# Altium schematic sheet OI-projet-P1.SchDoc(OI-projet-P1)
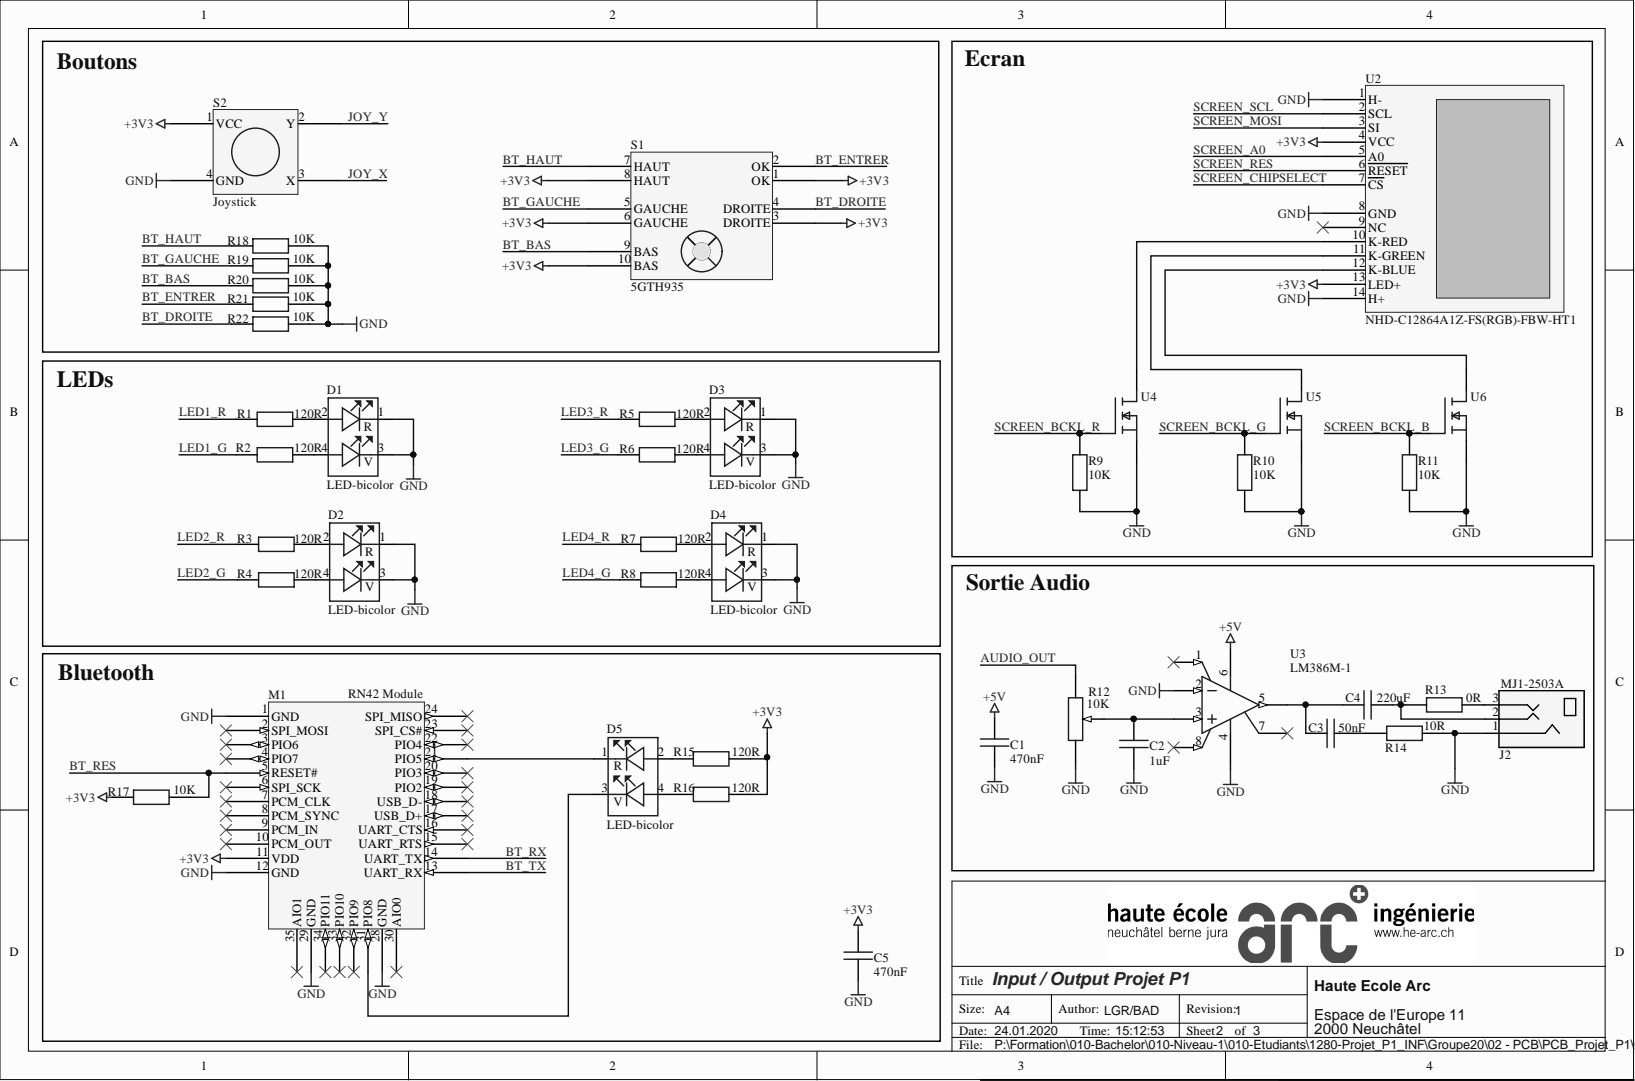
[diagram p0: OI-projet-P1.SchDoc]

## Parts
C1  470nF  [080501]
C2  1uF  [080501]
C3  50nF  [080501]
C4  220uF  [120601]
C5  470nF  [080501]
D1  LED-bicolor  [D00101-2]
D2  LED-bicolor  [D00101-2]
D3  LED-bicolor  [D00101-2]
D4  LED-bicolor  [D00101-2]
D5  LED-bicolor  [D00101-2]
J2  MJ1-2503A  [CUI_MJ1-2503A]
M1  RN42 Module  [RN42-LAND-3D]  (no BOM)
R1  120R  [080501]
R2  120R  [080501]
R3  120R  [080501]
R4  120R  [080501]
R5  120R  [080501]
R6  120R  [080501]
R7  120R  [080501]
R8  120R  [080501]
R9  10K  [080501]
R10  10K  [080501]
R11  10K  [080501]
R12  10K  [CT-6EP]
R13  0R  [080501]
R14  10R  [080501]
R15  120R  [080501]
R16  120R  [080501]
R17  10K  [080501]
R18  10K  [080501]
R19  10K  [080501]
R20  10K  [080501]
R21  10K  [080501]
R22  10K  [080501]
S1  5GTH935  [CONTROLMEC]
S2  Joystick  [Adafruit_3246]
U2  NHD-C12864A1Z-FS(RGB)-FBW-HT1  [NHD-C12864A1Z-FSW-FB(RGB)-HTT - integration 2]
U3  LM386M-1  [TI-D8_N]
U4  POWER-MOSFET  [SOT2301]  (value not drawn)
U5  POWER-MOSFET  [SOT2301]  (value not drawn)
U6  POWER-MOSFET  [SOT2301]  (value not drawn)

## Nets
+3V3: C5.1 M1.11(VDD) R15.2 R16.2 R17.1 S1.1(OK) S1.3(DROITE) S1.6(GAUCHE) S1.8(HAUT) S1.10(BAS) S2.1(VCC) U2.4(VCC) U2.13(LED+)
+5V: C1.1 U3.6
GND: C1.2 C2.2 C5.2 D1.1 D1.3 D2.1 D2.3 D3.1 D3.3 D4.1 D4.3 J2.1 M1.1(GND) M1.12(GND) M1.28(GND) M1.29(GND) R9.1 R10.1 R11.1 R12.1 R14.1 R18.2 R19.2 R20.2 R21.2 R22.2 S2.4(GND) U2.1(H-) U2.8(GND) U2.14(H+) U3.2 U3.4 U4.2 U5.2 U6.2
AUDIO_OUT: R12.3
BT_BAS: R20.1 S1.9(BAS)
BT_DROITE: R22.1 S1.4(DROITE)
BT_ENTRER: R21.1 S1.2(OK)
BT_GAUCHE: R19.1 S1.5(GAUCHE)
BT_HAUT: R18.1 S1.7(HAUT)
BT_RES: M1.5(RESET#) R17.2
BT_RX: M1.14(UART_TX)
BT_TX: M1.13(UART_RX)
JOY_X: S2.3(X)
JOY_Y: S2.2(Y)
LED1_G: R2.2
LED1_R: R1.2
LED2_G: R4.2
LED2_R: R3.1
LED3_G: R6.2
LED3_R: R5.2
LED4_G: R8.2
LED4_R: R7.2
SCREEN_A0: U2.5(A0)
SCREEN_BCKL_B: R11.2 U6.1
SCREEN_BCKL_G: R10.2 U5.1
SCREEN_BCKL_R: R9.2 U4.1
SCREEN_CHIPSELECT: U2.7(CS)
SCREEN_MOSI: U2.3(SI)
SCREEN_RES: U2.6(RESET)
SCREEN_SCL: U2.2(SCL)
NetC2_1: C2.1 R12.2 U3.3
NetC3_1: C3.1 C4.1 U3.5
NetC3_2: C3.2 R14.2
NetC4_2: C4.2 J2.2 R13.1
NetD1_2: D1.2 R1.1
NetD1_4: D1.4 R2.1
NetD2_2: D2.2 R3.2
NetD2_4: D2.4 R4.1
NetD3_2: D3.2 R5.1
NetD3_4: D3.4 R6.1
NetD4_2: D4.2 R7.1
NetD4_4: D4.4 R8.1
NetD5_1: D5.1 M1.21(PIO5)
NetD5_2: D5.2 R15.1
NetD5_3: D5.3 M1.31(PIO8)
NetD5_4: D5.4 R16.1
NetJ2_3: J2.3 R13.2
NetU2_10: U2.10(K-RED) U4.3
NetU2_11: U2.11(K-GREEN) U5.3
NetU2_12: U2.12(K-BLUE) U6.3

Not connected: M1 2(SPI_MOSI) 3(PIO6) 4(PIO7) 6(SPI_SCK) 7(PCM_CLK) 8(PCM_SYNC) 9(PCM_IN) 10(PCM_OUT) 15(UART_RTS) 16(UART_CTS) 17(USB_D+) 18(USB_D-) 19(PIO2) 20(PIO3) 22(PIO4) 23(SPI_CS#) 24(SPI_MISO) 30(AIO0) 32(PIO9) 33(PIO10) 34(PIO11) 35(AIO1); U2 9(NC); U3 1 7 8

## Library data of the parts
C1 C5 = Cap-470nF: Condensateur de 470nF 0805; Ref. Fournisseur: 399-8100-1-ND; Ref. Fabricant: C0805C474K5RACTU; Prix 1 unit (CHF): 0.35; Fournisseur: Digikey; Fabricant: KEMET; Auteur: BAD; Library Name: Schlib-P1-G20.SchLib; ComponentLink1Description: datasheet; Component Kind: Standard; ComponentLink1URL: P:\Formation\010-Bachelor\010-Niveau-1\010-Etudiants\1280-Projet_P1_INF\Groupe20\01 - Documentation\01 - Composants electronique\11 - Composants passif\02 - Condensateur\KEM_C1002_X7R_SMD.pdf
C2 = Cap-1uF: Condensateur de 1uF 0805; Ref. Fournisseur: 399-7409-1-ND; Ref. Fabricant: C0805C105K5RACTU; Prix 1 unit (CHF): 0.4; Fournisseur: Digikey; Fabricant: KEMET; Auteur: BAD; Library Name: Schlib-P1-G20.SchLib; ComponentLink1Description: datasheet; Component Kind: Standard; ComponentLink1URL: P:\Formation\010-Bachelor\010-Niveau-1\010-Etudiants\1280-Projet_P1_INF\Groupe20\01 - Documentation\01 - Composants electronique\11 - Composants passif\02 - Condensateur\KEM_C1002_X7R_SMD.pdf
C3 = Cap-50nF: Condensateur de 50nF 0805; Ref. Fournisseur: 311-1431-1-ND; Ref. Fabricant: CC0805KRX7R9BB473; Prix 1 unit (CHF): 0.13; Fournisseur: Digikey; Fabricant: Yageo; Auteur: BAD; Library Name: Schlib-P1-G20.SchLib; ComponentLink1Description: datasheet; Component Kind: Standard; ComponentLink1URL: P:\Formation\010-Bachelor\010-Niveau-1\010-Etudiants\1280-Projet_P1_INF\Groupe20\01 - Documentation\01 - Composants electronique\11 - Composants passif\02 - Condensateur\C0GNP0-Dielectric.pdf
C4 = Cap-220uF: Condensateur de 220uF 1206; Ref. Fournisseur: 490-13970-1-ND; Ref. Fabricant: GRM31CR60J227ME11L; Prix 1 unit (CHF): 1.50; Fournisseur: Digikey; Fabricant: Murata Electronics; Auteur: BAD; Library Name: Schlib-P1-G20.SchLib; ComponentLink1Description: datasheet; Component Kind: Standard; ComponentLink1URL: P:\Formation\010-Bachelor\010-Niveau-1\010-Etudiants\1280-Projet_P1_INF\Groupe20\01 - Documentation\01 - Composants electronique\11 - Composants passif\02 - Condensateur\GRM31CR60J227ME11-01.pdf
D1-D5 = XZMDKVG45S: Led bicolore; Ref. Fournisseur: 1497-1181-1-ND; Prix 1 unit (CHF): 0.54; Fournisseur: Digikey; Fabricant: SunLED; Auteur: BAD; Ref. Fabricant: XZMDKVG45S; Library Name: Schlib-P1-G20.SchLib; ComponentLink1Description: datasheet; Component Kind: Standard; ComponentLink1URL: P:\Formation\010-Bachelor\010-Niveau-1\010-Etudiants\1280-Projet_P1_INF\Groupe20\01 - Documentation\01 - Composants electronique\08 - LED\XZMDKVG45S.pdf
J2 = MJ1-2503A: Ref. Fournisseur: CP1-2503AM-ND; Prix 1 unit (CHF): 0.67; Fournisseur: Digikey; Fabricant: CUI Devices; Auteur: BAD; Ref. Fabricant: MJ1-2503A; Library Name: Schlib-P1-G20.SchLib; ComponentLink1Description: datasheet; Component Kind: Standard; ComponentLink1URL: P:\Formation\010-Bachelor\010-Niveau-1\010-Etudiants\1280-Projet_P1_INF\Groupe20\01 - Documentation\01 - Composants electronique\10 - Connecteurs\jack\jack-mj1-2503a.pdf
M1 = RN42MOD: Ref. Fournisseur: 765-RN-42; Ref. Fabricant: RN42-I/RM; Prix 1 unit (CHF): 15.59; Fournisseur: Mouser; Fabricant: Microchip; Auteur: BAD; Library Name: Schlib-P1-G20.SchLib; Description: RN42 Module; ComponentLink1Description: datasheet; ComponentLink1URL: P:\Formation\010-Bachelor\010-Niveau-1\010-Etudiants\1280-Projet_P1_INF\Groupe20\01 - Documentation\01 - Composants electronique\09 - Bluetooth\RN42-I.pdf
R1-R8 R15 R16 = Res-120R-0805: Résistance 120 ohm 0805 0.125W; Ref. Fournisseur: 311-120CRCT-ND; Ref. Fabricant: RC0805FR-07120RL; Prix 1 unit (CHF): 0.10; Fournisseur: Digikey; Fabricant: Yageo; Auteur: BAD; Library Name: Schlib-P1-G20.SchLib; ComponentLink1Description: datasheet; Component Kind: Standard; ComponentLink1URL: P:\Formation\010-Bachelor\010-Niveau-1\010-Etudiants\1280-Projet_P1_INF\Groupe20\01 - Documentation\01 - Composants electronique\11 - Composants passif\01 - Résistances\res-100K-0805.pdf
R9-R11 R17-R22 = Res-10K-0805: Résistance 10 K ohm 0805 0.125W; Ref. Fournisseur: 311-10.0KCRCT-ND; Ref. Fabricant: RC0805FR-0710KL; Prix 1 unit (CHF): 0.10; Fournisseur: Digikey; Fabricant: Yageo; Auteur: BAD; Library Name: Schlib-P1-G20.SchLib; ComponentLink1Description: datasheet; Component Kind: Standard; ComponentLink1URL: P:\Formation\010-Bachelor\010-Niveau-1\010-Etudiants\1280-Projet_P1_INF\Groupe20\01 - Documentation\01 - Composants electronique\11 - Composants passif\01 - Résistances\res-100K-0805.pdf
R12 = CT6EP103: Potentiomètre; Ref. Fournisseur: CT6EP103-ND; Prix 1 unit (CHF): 0.78; Fournisseur: Digikey; Fabricant: Nidec Copal Electronics; Auteur: BAD; Ref. Fabricant: CT6EP103; Library Name: Schlib-P1-G20.SchLib; ComponentLink1Description: datasheet; Component Kind: Standard; ComponentLink1URL: P:\Formation\010-Bachelor\010-Niveau-1\010-Etudiants\1280-Projet_P1_INF\Groupe20\01 - Documentation\01 - Composants electronique\11 - Composants passif\04 - Potentiomètre\10 K\ct-6.pdf
R13 = Res_0R-0805: Résistance 0 R 0805 0.25W; Ref. Fournisseur: RMCF0805ZT0R00CT-ND; Ref. Fabricant: RMCF0805ZT0R00; Prix 1 unit (CHF): 0.10; Fournisseur: Digikey; Fabricant: Stackpole Electronics Inc; Auteur: BAD; Library Name: Schlib-P1-G20.SchLib; ComponentLink1Description: datasheet; Component Kind: Standard; ComponentLink1URL: P:\Formation\010-Bachelor\010-Niveau-1\010-Etudiants\1280-Projet_P1_INF\Groupe20\01 - Documentation\01 - Composants electronique\11 - Composants passif\01 - Résistances\sei-packaging.pdf
R14 = Res-10R-0805: Résistance 10 R 0805 0.25W; Ref. Fournisseur: RNCP0805FTD10R0CT-ND; Ref. Fabricant: RNCP0805FTD10R0; Prix 1 unit (CHF): 0.10; Fournisseur: Digikey; Fabricant: Stackpole Electronics Inc; Auteur: BAD; Library Name: Schlib-P1-G20.SchLib; ComponentLink1Description: datasheet; Component Kind: Standard; ComponentLink1URL: P:\Formation\010-Bachelor\010-Niveau-1\010-Etudiants\1280-Projet_P1_INF\Groupe20\01 - Documentation\01 - Composants electronique\11 - Composants passif\01 - Résistances\res-150-0805.pdf
S1 = CONTROLMEC: digikey :  5 x 679-2612-ND   4 x 679-2303-ND   1 x 679-2302-ND; Ref. Fournisseur: 5 x 679-2612-ND / 4 x 679-2303-ND / 1 x 679-2302-ND; Ref. Fabricant: 5 x 5GTH935 / 4 x 1ZB09D13606 / 1 x 1ZC0911806; Prix 1 unit (CHF): 24.61; Fabricant: APEM; Auteur: BAD; Library Name: Schlib-P1-G20.SchLib; Fournisseur: Digikey; ComponentLink1Description: datasheet; Component Kind: Standard; ComponentLink1URL: P:\Formation\010-Bachelor\010-Niveau-1\010-Etudiants\1280-Projet_P1_INF\Groupe20\01 - Documentation\01 - Composants electronique\07 - Boutons et joystick\MEC-directionnel\MEC-switches-serie-MULTIMEC3F.pdf
S2 = Analog mini Thumbstick: Ref. Fournisseur: 2765 / 3246; Prix 1 unit (CHF): 3.98; Fournisseur: Adafruit; Auteur: BAD; Ref. Fabricant: 2765 / 3246; Library Name: Schlib-P1-G20.SchLib; Fabricant: Adafruit; ComponentLink1Description: datasheet; Component Kind: Standard; ComponentLink1URL: P:\Formation\010-Bachelor\010-Niveau-1\010-Etudiants\1280-Projet_P1_INF\Groupe20\01 - Documentation\01 - Composants electronique\07 - Boutons et joystick\Joystick\dimmentions.jpg
U2 = NHD-C12864A1Z-FS(RGB)-FBW-HTT: Ecran 128x64 px RGB backlight; Ref. Fournisseur: NHD-C12864A1Z-FS(RGB)-FBW-HT1-ND; Prix 1 unit (CHF): 24.88; Fournisseur: Digikey; Fabricant: Newhaven Display; Auteur: BAD; Ref. Fabricant: NHD-C12864A1Z-FS(RGB)-FBW-HT1; Library Name: Schlib-P1-G20.SchLib; ComponentLink1Description: datasheet; Component Kind: Standard; ComponentLink1URL: P:\Formation\010-Bachelor\010-Niveau-1\010-Etudiants\1280-Projet_P1_INF\Groupe20\01 - Documentation\01 - Composants electronique\06 - Ecran\NHD-C12864A1Z-FSRGB-FBW-HT1.pdf
U3 = LM386M-1: Low Voltage Audio Power Amplifier, 0 to 70 degC, 8-pin SOIC (D8); Manufacturer: Texas Instruments; SubFamily: Mid/High-Power Amplifier; Ref. Fournisseur: LM386MX-1/NOPBCT-ND; Ref. Fabricant: LM386M-1-ND; Prix 1 unit (CHF): 1.04; Max. Number of Speaker Outputs: 1; Input Type: Analog; Fournisseur: Digikey; Supplier Part Number 4: 926-LM386M-1; Supplier Part Number 3: 92F292; Supplier Part Number 2: 9488324; Supplier Part Number 1: LM386M-1-ND; Supplier 4: Mouser; Supplier 3: Newark; Supplier 2: Farnell; Supplier 1: Digi-Key; PartNumber: LM386M-1; PackageVersion: 4040047-3/M, 06/2011; PackageReference: D8; PackageDescription: 8-Pin Plastic Small Outline, Body 4.9 x 3.9 mm, Pitch 1.27 mm; Mounting Technology: Surface Mount; Fabricant: Texas Instruments; DatasheetVersion: SNAS545A; ComponentLink3URL: http://www.ti.com/litv/pdf/msoi002j; ComponentLink3Description: Package Specification; ComponentLink2URL: http://www.ti.com/general/docs/lit/getliterature.tsp?genericPartNumber=LM386&fileType=pdf; ComponentLink2Description: Datasheet; ComponentLink1URL: http://www.ti.com/; ComponentLink1Description: Manufacturer URL; Component Kind: Standard; Code_JEDEC: MS-012; Library Name: TI Amplifiers and Linear Special Function Amplifier.IntLib
U4-U6 = IRLML6344TRPBF: Transistor MOSFET Courant drain continu 5A  Logic Level - 2.5V à 4.5V; Ref. Fournisseur: IRLML6344TRPBFCT-ND; Prix 1 unit (CHF): 0.43; Fournisseur: Digikey; Fabricant: Infineon Technologies; Auteur: BAD; Ref. Fabricant: IRLML6344TRPBF; Library Name: Schlib-P1-G20.SchLib; ComponentLink1Description: datasheet; Component Kind: Standard; ComponentLink1URL: P:\Formation\010-Bachelor\010-Niveau-1\010-Etudiants\1280-Projet_P1_INF\Groupe20\01 - Documentation\01 - Composants electronique\12 - Transistor\00 - MOSFET\IRLML6344.pdf
